# Altium schematic sheet breakout.SchDoc(breakout)
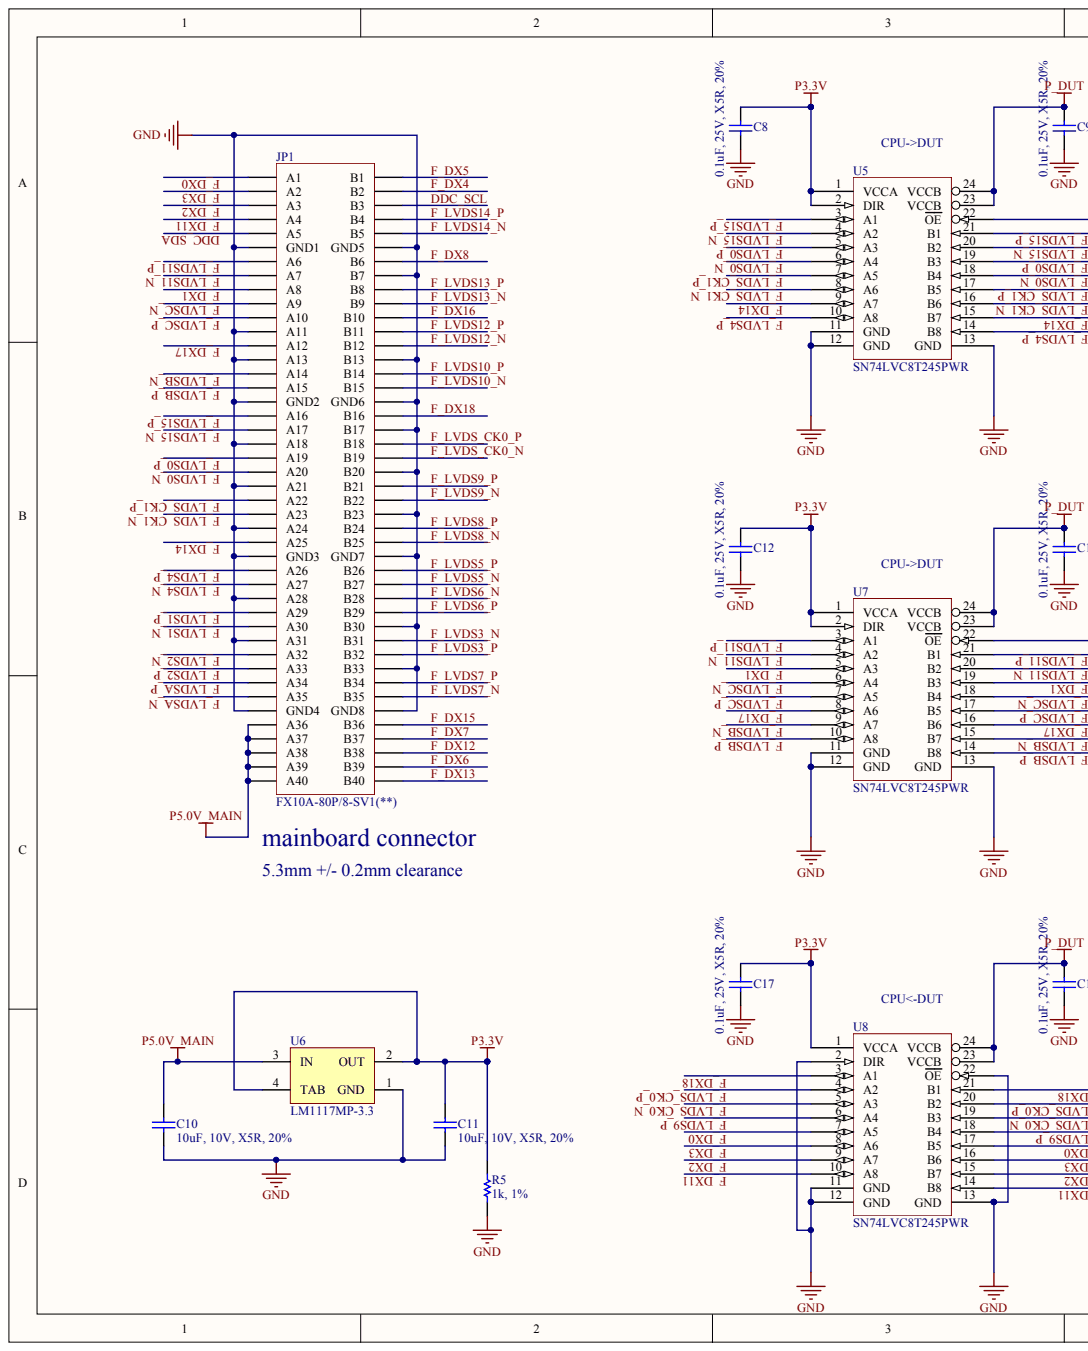
[diagram p0: breakout.SchDoc, left]
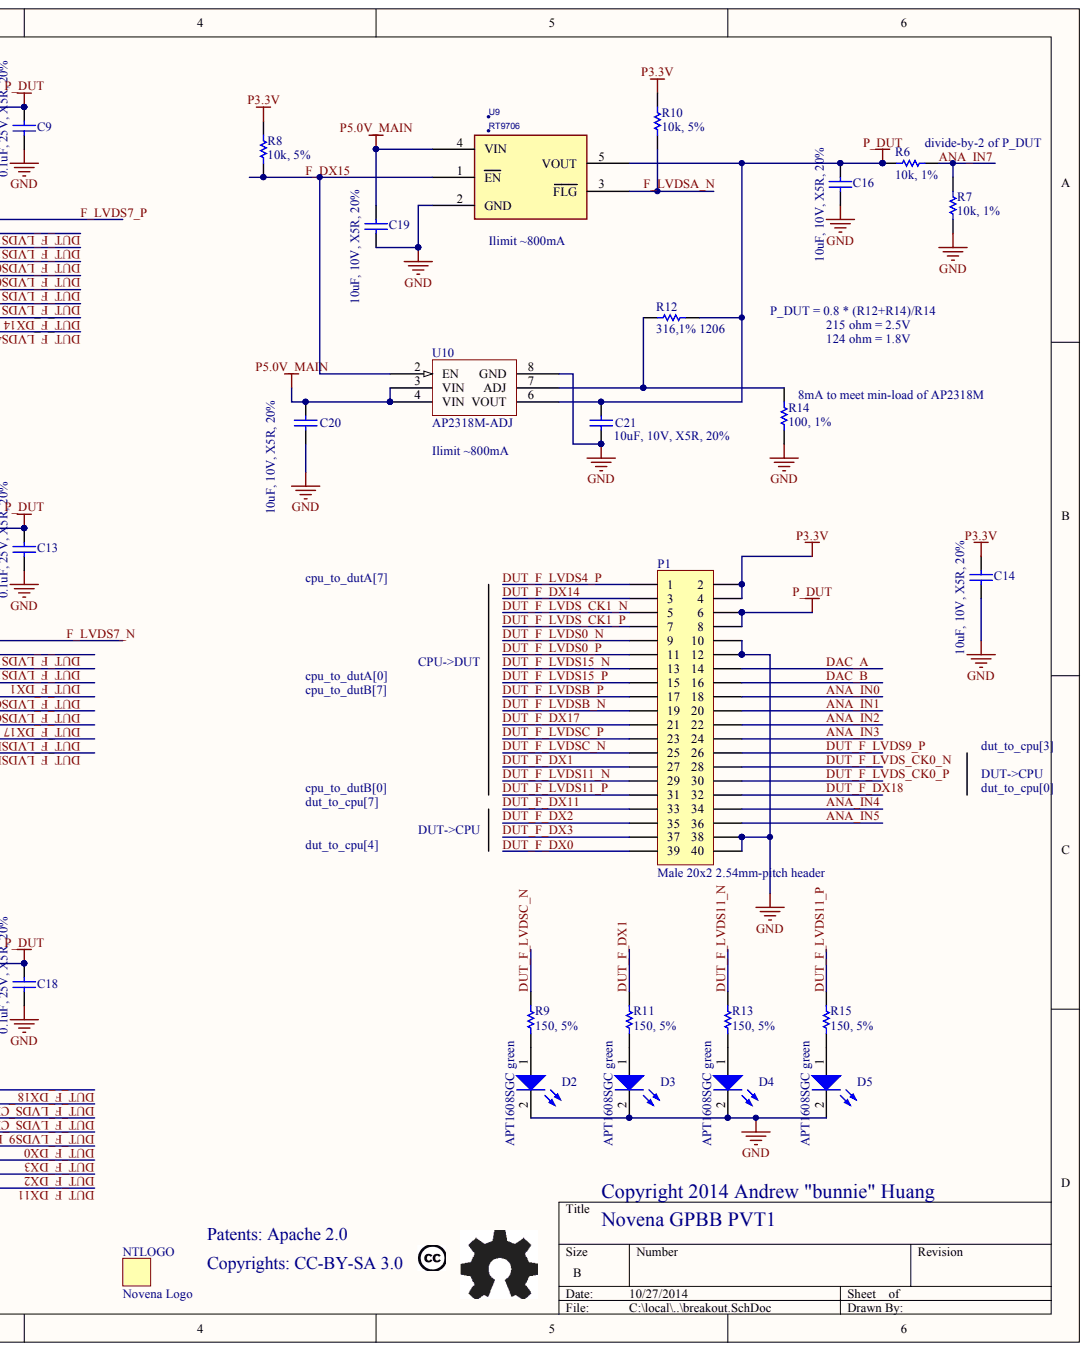
[diagram p1: breakout.SchDoc, right]

## Parts
C8  0.1uF, 25V, X5R, 20%
C9  0.1uF, 25V, X5R, 20%
C10  10uF, 10V, X5R, 20%
C11  10uF, 10V, X5R, 20%
C12  0.1uF, 25V, X5R, 20%
C13  0.1uF, 25V, X5R, 20%
C14  10uF, 10V, X5R, 20%
C16  10uF, 10V, X5R, 20%
C17  0.1uF, 25V, X5R, 20%
C18  0.1uF, 25V, X5R, 20%
C19  10uF, 10V, X5R, 20%
C20  10uF, 10V, X5R, 20%
C21  10uF, 10V, X5R, 20%
D2  APT1608SGC green
D3  APT1608SGC green
D4  APT1608SGC green
D5  APT1608SGC green
JP1  FX10A-80P/8-SV1(**)
NTLOGO  Novena Logo
P1  Male 20x2 2.54mm-pitch header
R5  1k, 1%
R6  10k, 1%
R7  10k, 1%
R8  10k, 5%
R9  150, 5%
R10  10k, 5%
R11  150, 5%
R12  316,1% 1206
R13  150, 5%
R14  100, 1%
R15  150, 5%
U5  SN74LVC8T245PWR
U6  LM1117MP-3.3
U7  SN74LVC8T245PWR
U8  SN74LVC8T245PWR
U9  RT9706
U10  AP2318M-ADJ

## Nets
GND: C8.2 C9.2 C10.2 C11.2 C12.2 C13.2 C14.2 C16.2 C17.2 C18.2 C19.2 C20.2 C21.2 D2.2 D3.2 D4.2 D5.2 JP1.A11 JP1.A13 JP1.A18 JP1.A21 JP1.A24 JP1.A28 JP1.A31 JP1.B7 JP1.B13 JP1.B17 JP1.B20 JP1.B23 JP1.B30 JP1.B33 JP1.GND1 JP1.GND2 JP1.GND3 JP1.GND4 JP1.GND5 JP1.GND6 JP1.GND7 JP1.GND8 P1.10 P1.12 P1.38 P1.40 R5.2 R7.2 R14.1 U5.11(GND) U5.12(GND) U5.13(GND) U6.1(GND) U7.11(GND) U7.12(GND) U7.13(GND) U8.2(DIR) U8.11(GND) U8.12(GND) U8.13(GND) U8.22(OE) U9.2(GND) U10.8(GND)
P3.3V: C8.1 C11.1 C12.1 C14.1 C17.1 P1.2 P1.4 R5.1 R8.2 R10.2 U5.1(VCCA) U5.2(DIR) U6.2(OUT) U6.4(TAB) U7.1(VCCA) U7.2(DIR) U8.1(VCCA)
P5.0V_MAIN: C10.1 C19.1 C20.1 JP1.A36 JP1.A37 JP1.A38 JP1.A39 JP1.A40 U6.3(IN) U9.4(VIN) U10.3(VIN) U10.4(VIN)
P_DUT: C9.1 C13.1 C16.1 C18.1 C21.1 P1.6 P1.8 R6.2 R12.1 U5.23(VCCB) U5.24(VCCB) U7.23(VCCB) U7.24(VCCB) U8.23(VCCB) U8.24(VCCB) U9.5(VOUT) U10.6(VOUT)
ANA_IN0: P1.18
ANA_IN1: P1.20
ANA_IN2: P1.22
ANA_IN3: P1.24
ANA_IN4: P1.34
ANA_IN5: P1.36
ANA_IN7: R6.1 R7.1
DAC_A: P1.14
DAC_B: P1.16
DDC_SCL: JP1.B3
DDC_SDA: JP1.A5
DUT_F_DX0: P1.39 U8.17(B5)
DUT_F_DX1: P1.27 R11.2 U7.19(B3)
DUT_F_DX2: P1.35 U8.15(B7)
DUT_F_DX3: P1.37 U8.16(B6)
DUT_F_DX11: P1.33 U8.14(B8)
DUT_F_DX14: P1.3 U5.15(B7)
DUT_F_DX17: P1.21 U7.16(B6)
DUT_F_DX18: P1.32 U8.21(B1)
DUT_F_LVDS0_N: P1.9 U5.18(B4)
DUT_F_LVDS0_P: P1.11 U5.19(B3)
DUT_F_LVDS4_P: P1.1 U5.14(B8)
DUT_F_LVDS9_P: P1.26 U8.18(B4)
DUT_F_LVDS11_N: P1.29 R13.2 U7.20(B2)
DUT_F_LVDS11_P: P1.31 R15.2 U7.21(B1)
DUT_F_LVDS15_N: P1.13 U5.20(B2)
DUT_F_LVDS15_P: P1.15 U5.21(B1)
DUT_F_LVDS_CK0_N: P1.28 U8.19(B3)
DUT_F_LVDS_CK0_P: P1.30 U8.20(B2)
DUT_F_LVDS_CK1_N: P1.5 U5.16(B6)
DUT_F_LVDS_CK1_P: P1.7 U5.17(B5)
DUT_F_LVDSB_N: P1.19 U7.15(B7)
DUT_F_LVDSB_P: P1.17 U7.14(B8)
DUT_F_LVDSC_N: P1.25 R9.2 U7.18(B4)
DUT_F_LVDSC_P: P1.23 U7.17(B5)
F_DX0: JP1.A1 U8.7(A5)
F_DX1: JP1.A8 U7.5(A3)
F_DX2: JP1.A3 U8.9(A7)
F_DX3: JP1.A2 U8.8(A6)
F_DX4: JP1.B2
F_DX5: JP1.B1
F_DX6: JP1.B39
F_DX7: JP1.B37
F_DX8: JP1.B6
F_DX11: JP1.A4 U8.10(A8)
F_DX12: JP1.B38
F_DX13: JP1.B40
F_DX14: JP1.A25 U5.9(A7)
F_DX15: JP1.B36 R8.1 U9.1(EN) U10.2(EN)
F_DX16: JP1.B10
F_DX17: JP1.A12 U7.8(A6)
F_DX18: JP1.B16 U8.3(A1)
F_LVDS0_N: JP1.A20 U5.6(A4)
F_LVDS0_P: JP1.A19 U5.5(A3)
F_LVDS1_N: JP1.A30
F_LVDS1_P: JP1.A29
F_LVDS2_N: JP1.A32
F_LVDS2_P: JP1.A33
F_LVDS3_N: JP1.B31
F_LVDS3_P: JP1.B32
F_LVDS4_N: JP1.A27
F_LVDS4_P: JP1.A26 U5.10(A8)
F_LVDS5_N: JP1.B27
F_LVDS5_P: JP1.B26
F_LVDS6_N: JP1.B28
F_LVDS6_P: JP1.B29
F_LVDS7_N: JP1.B35 U7.22(OE)
F_LVDS7_P: JP1.B34 U5.22(OE)
F_LVDS8_N: JP1.B25
F_LVDS8_P: JP1.B24
F_LVDS9_N: JP1.B22
F_LVDS9_P: JP1.B21 U8.6(A4)
F_LVDS10_N: JP1.B15
F_LVDS10_P: JP1.B14
F_LVDS11_N: JP1.A7 U7.4(A2)
F_LVDS11_P: JP1.A6 U7.3(A1)
F_LVDS12_N: JP1.B12
F_LVDS12_P: JP1.B11
F_LVDS13_N: JP1.B9
F_LVDS13_P: JP1.B8
F_LVDS14_N: JP1.B5
F_LVDS14_P: JP1.B4
F_LVDS15_N: JP1.A17 U5.4(A2)
F_LVDS15_P: JP1.A16 U5.3(A1)
F_LVDS_CK0_N: JP1.B19 U8.5(A3)
F_LVDS_CK0_P: JP1.B18 U8.4(A2)
F_LVDS_CK1_N: JP1.A23 U5.8(A6)
F_LVDS_CK1_P: JP1.A22 U5.7(A5)
F_LVDSA_N: JP1.A35 R10.1 U9.3(FLG)
F_LVDSA_P: JP1.A34
F_LVDSB_N: JP1.A14 U7.9(A7)
F_LVDSB_P: JP1.A15 U7.10(A8)
F_LVDSC_N: JP1.A9 U7.6(A4)
F_LVDSC_P: JP1.A10 U7.7(A5)
NetD2_1: D2.1 R9.1
NetD3_1: D3.1 R11.1
NetD4_1: D4.1 R13.1
NetD5_1: D5.1 R15.1
NetR12_2: R12.2 R14.2 U10.7(ADJ)

## Library data of the parts
R5-R15 = (no symbol name): Value: 100k; Published: 8-Jun-2000; LatestRevisionDate: 17-Jul-2002; LatestRevisionNote: Re-released for DXP Platform.; PackageReference: AXIAL-0.3; Publisher: Altium Limited
U6 = (no symbol name): Manufacturer: National Semiconductor; CompType: LDO IC; PackageTopMarking: XYTT*N05A; PowerWise: N; Published: 4-Dec-2008; DatasheetVersion: Apr-2006; PackageVersion: revB, Apr-2003; PackageReference: MP04A; PackageDescription: Molded SOT-223, 6.50 x 3.56 x 1.6mm Body, 4 Lead, 2.3mm Pitch; PartNumber: LM1117MP-3.3; RoHS: O; PinCount: 4; DigiKeyPartNumber: LM1117MP-3.3CT-ND; Code_JEDEC: TO-261-AA; Code_IPC: SOT223-4; ComponentLink1Description: LM1117 Datasheet; ComponentLink1URL: http://www.national.com/ds/LM/LM1117.pdf; ComponentLink2Description: LM1117 Web Page; ComponentLink2URL: http://www.national.com/pf/LM/LM1117.html; ComponentLink3Description: MP04A Package Page; ComponentLink3URL: http://www.national.com/packaging/folders/mp04a.html; Publisher: Altium Limited
U9 = (no symbol name): AGILE_PN: 315-77830; MFG_NAME01: RICHTEK; MFG_PN01: RT9706PB; CAD_APPROVED: Yes; ROHS: Yes; HEIGHT: 1.295MM
C8-C14 C16-C21 D2-D5 JP1 NTLOGO P1 U5 U7 U8 U10 = (no symbol name)
  C8-C14 C16-C21: Published: 8-Jun-2000; LatestRevisionDate: 17-Jul-2002; LatestRevisionNote: Re-released for DXP Platform.; PackageReference: C1206
  D2-D5: Published: 8-Jun-2000; LatestRevisionDate: 17-Jul-2002; LatestRevisionNote: Re-released for DXP Platform.; PackageVersion: Feb-2002; PackageReference: 3.2X1.6X1.1
  P1: LatestRevisionDate: 17-Jul-2002; LatestRevisionNote: Re-released for DXP Platform.
  C8-C14 C16-C21 D2-D5 P1: Publisher: Altium Limited
